# Altium schematic sheet espbat.SchDoc
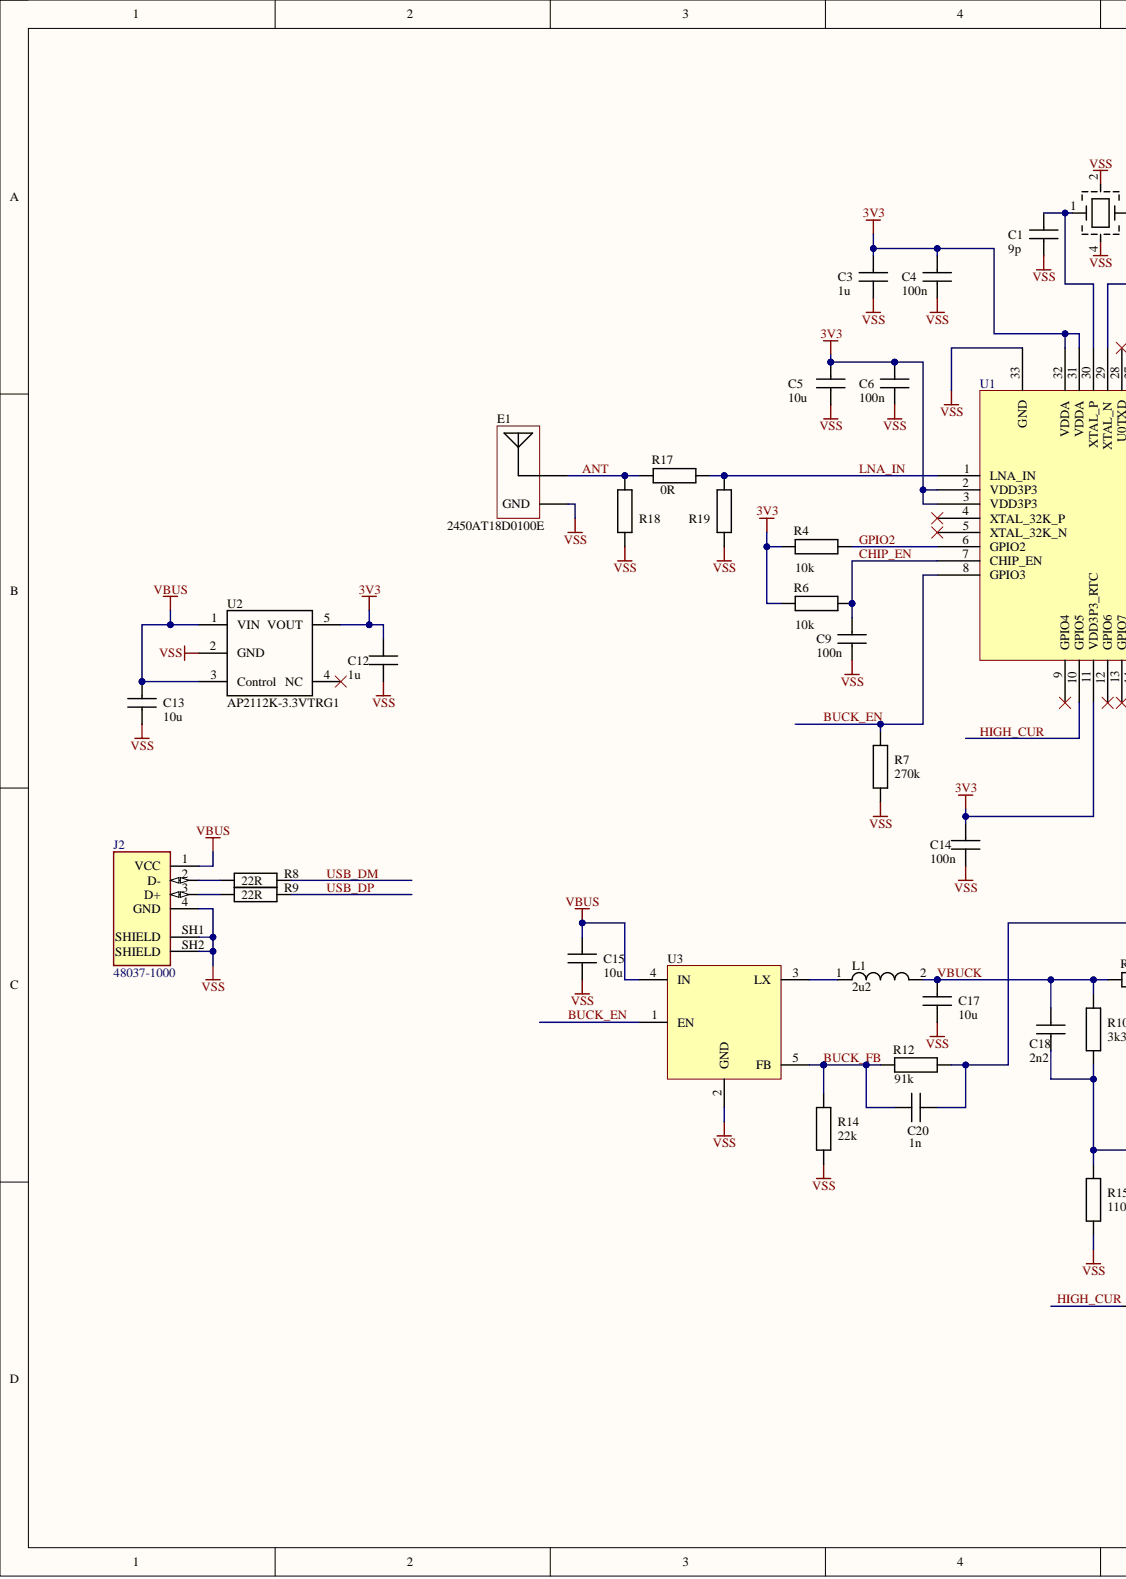
[diagram p0: espbat.SchDoc, left]
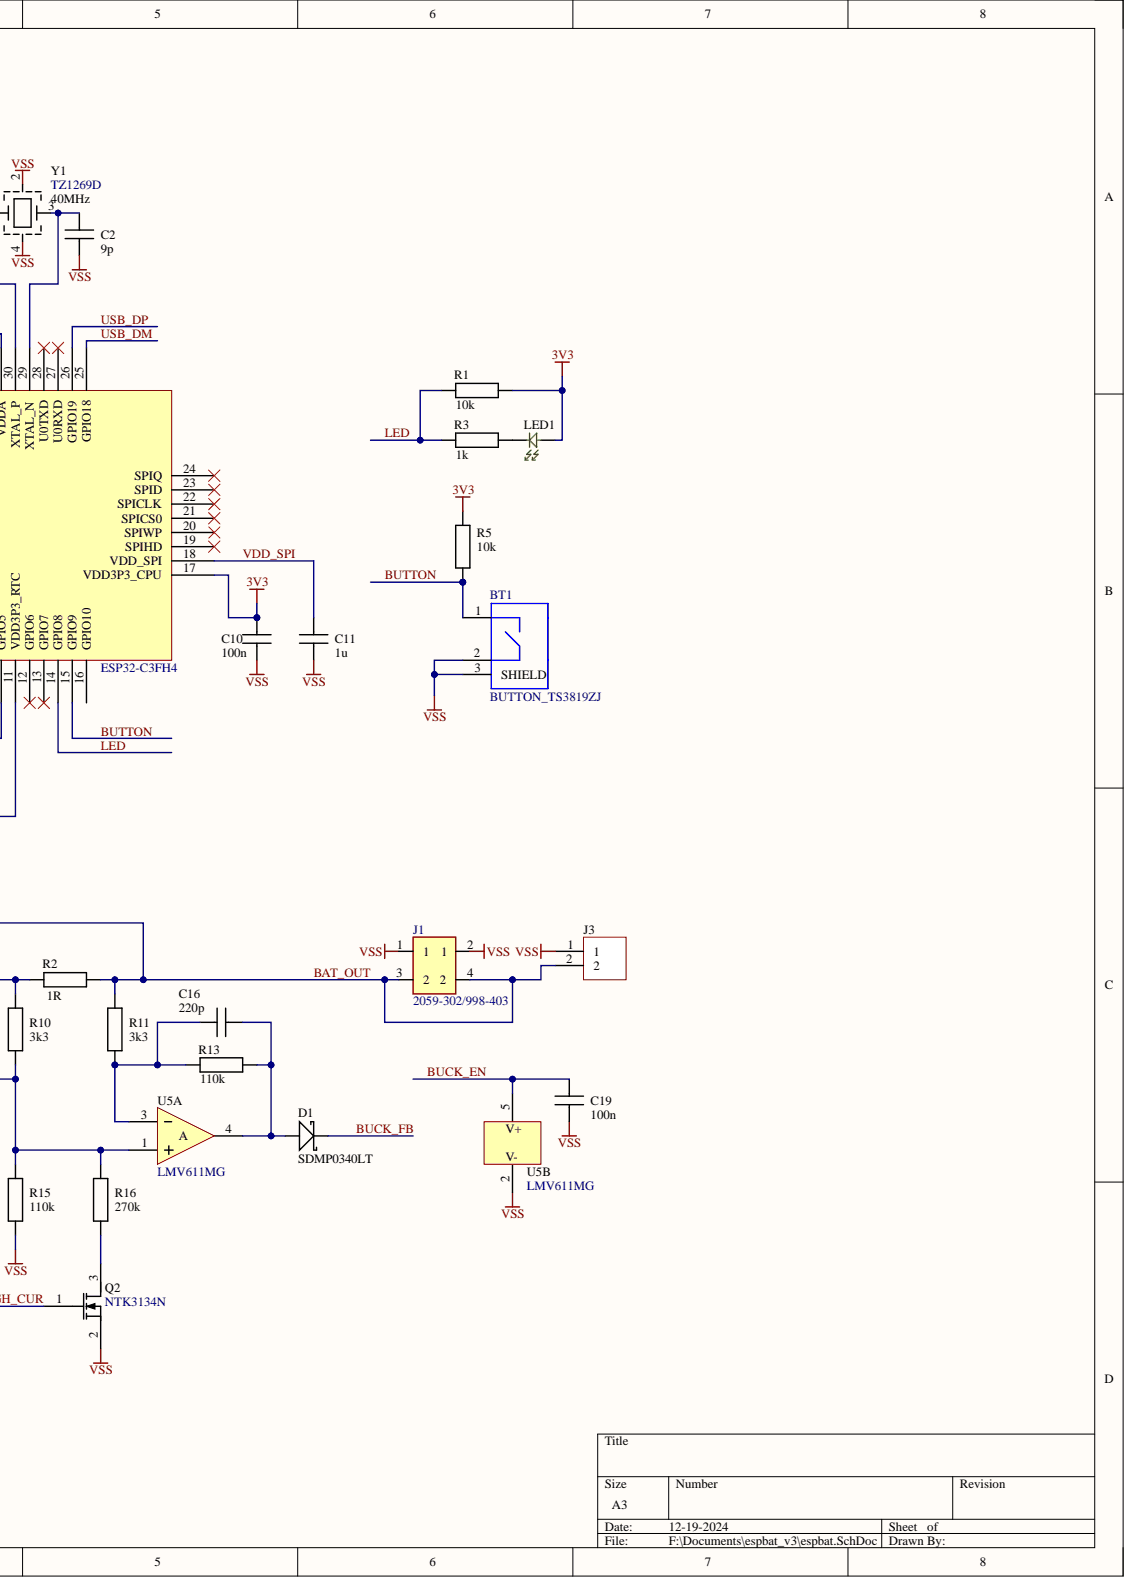
[diagram p1: espbat.SchDoc, right]

## Parts
BT1  BUTTON_TS3819ZJ  [TS3819ZJ]
C1  value 9p  [CAP_0201]  (hidden comment: CAP_0201)
C2  value 9p  [CAP_0201]  (hidden comment: CAP_0201)
C3  value 1u  [CAP_0201]  (hidden comment: CAP_0201)
C4  value 100n  [CAP_0201]  (hidden comment: CAP_0201)
C5  value 10u  [CAP_0402]  (hidden comment: CAP_0402)
C6  value 100n  [CAP_0201]  (hidden comment: CAP_0201)
C9  value 100n  [CAP_0201]  (hidden comment: CAP_0201)
C10  value 100n  [CAP_0201]  (hidden comment: CAP_0201)
C11  value 1u  [CAP_0201]  (hidden comment: CAP_0201)
C12  value 1u  [CAP_0201]  (hidden comment: CAP_0201)
C13  value 10u  [CAP_0402]  (hidden comment: CAP_0402)
C14  value 100n  [CAP_0201]  (hidden comment: CAP_0201)
C15  value 10u  [CAP_0402]  (hidden comment: CAP_0402)
C16  value 220p  [CAP_0201]  (hidden comment: CAP_0201)
C17  value 10u  [CAP_0402]  (hidden comment: CAP_0402)
C18  value 2n2  [CAP_0201]  (hidden comment: CAP_0201)
C19  value 100n  [CAP_0201]  (hidden comment: CAP_0201)
C20  value 1n  [CAP_0201]  (hidden comment: CAP_0201)
D1  SDMP0340LT  [sot523]
E1  Manufacturer_Part_Number: 2450AT18D0100E  [ANT_2450AT18D0100E_ALT]
J1  2059-302/998-403  [WAGO_2059-302/998-403]
J2  48037-1000  [MOLEX_48037-1000]
J3  CON_TERMINAL_BLOCK_2pos_2.54  [691210910002]  (value not drawn)
L1  2u2  [Inductor_Bourns-SRN2512]
LED1  (no value)  [LED_0603]
Q2  Manufacturer_Part_Number: NTK3134N  [SOT723]
R1  value 10k  [RES_0201]  (hidden comment: RES_0201)
R2  value 1R  [RES_0603]  (hidden comment: RES_0603)
R3  value 1k  [RES_0201]  (hidden comment: RES_0201)
R4  value 10k  [RES_0201]  (hidden comment: RES_0201)
R5  value 10k  [RES_0201]  (hidden comment: RES_0201)
R6  value 10k  [RES_0201]  (hidden comment: RES_0201)
R7  value 270k  [RES_0201]  (hidden comment: RES_0201)
R8  value 22R  [RES_0201]  (hidden comment: RES_0201)
R9  value 22R  [RES_0201]  (hidden comment: RES_0201)
R10  value 3k3  [RES_0201]  (hidden comment: RES_0201)
R11  value 3k3  [RES_0201]  (hidden comment: RES_0201)
R12  value 91k  [RES_0201]  (hidden comment: RES_0201)
R13  value 110k  [RES_0201]  (hidden comment: RES_0201)
R14  value 22k  [RES_0201]  (hidden comment: RES_0201)
R15  value 110k  [RES_0201]  (hidden comment: RES_0201)
R16  value 270k  [RES_0201]  (hidden comment: RES_0201)
R17  value 0R  [RES_0201]  (hidden comment: RES_0201)
R18  RES_0201  [RES_0201]  (value not drawn)
R19  RES_0201  [RES_0201]  (value not drawn)
U1  ESP32-C3FH4  [QFN50P500X500X80-32N]
U2  Manufacturer_Part_Number: AP2112K-3.3VTRG1  [SOT23-5]
U3  (no value)  [SOT23-5]
U5  Manufacturer_Part_Number: LMV611MG  [SOT353]  (hidden comment: LMV611DCK)  (parts A,B)
Y1  value 40MHz  Manufacturer_Part_Number: TZ1269D  [XTAL_2016]  (hidden comment: XTAL_2016)

## Nets
3V3: C3.2 C4.2 C5.2 C6.2 C10.2 C12.2 C14.2 LED1.A R1.2 R4.2 R5.1 R6.2 U1.2(VDD3P3) U1.3(VDD3P3) U1.11(VDD3P3_RTC) U1.17(VDD3P3_CPU) U1.31(VDDA) U1.32(VDDA) U2.5(VOUT)
VBUS: C13.2 C15.2 J2.1(VCC) U2.1(VIN) U2.3(Control) U3.4(IN)
VSS: BT1.2 BT1.3 C1.1 C2.1 C3.1 C4.1 C5.1 C6.1 C9.1 C10.1 C11.1 C12.1 C13.1 C14.1 C15.1 C17.1 C19.1 E1.2 J1.1 J1.2 J2.4(GND) J2.SH1(SHIELD) J2.SH2(SHIELD) J3.1 Q2.2 R7.1 R14.1 R15.2 R18.2 R19.2 U1.33(GND) U2.2(GND) U3.2(GND) U5.2(V-) Y1.2 Y1.4
ANT: E1.1 R17.2 R18.1
BAT_OUT: C20.2 J1.3 J1.4 J3.2 R2.2 R11.1 R12.1
BUCK_EN: C19.2 R7.2 U1.8(GPIO3) U3.1(EN) U5.5(V+)
BUCK_FB: C20.1 D1.3 R12.2 R14.2 U3.5(FB)
BUTTON: BT1.1 R5.2 U1.15(GPIO9)
CHIP_EN: C9.2 R6.1 U1.7(CHIP_EN)
GPIO2: R4.1 U1.6(GPIO2)
HIGH_CUR: Q2.1 U1.10(GPIO5)
LED: R1.1 R3.1 U1.14(GPIO8)
LNA_IN: R17.1 R19.1 U1.1(LNA_IN)
USB_DM: R8.1 U1.25(GPIO18)
USB_DP: R9.1 U1.26(GPIO19)
VBUCK: C17.2 C18.2 L1.2 R2.1 R10.1
VDD_SPI: C11.2 U1.18(VDD_SPI)
NetC1_2: C1.2 U1.30(XTAL_P) Y1.1
NetC2_2: C2.2 U1.29(XTAL_N) Y1.3
NetC16_1: C16.1 D1.1 R13.2 U5.4
NetC16_2: C16.2 R11.2 R13.1 U5.3
NetC18_1: C18.1 R10.2 R15.1 R16.1 U5.1
NetJ2_2: J2.2(D-) R8.2
NetJ2_3: J2.3(D+) R9.2
NetL1_1: L1.1 U3.3(LX)
NetLED1_C: LED1.C R3.2
NetQ2_3: Q2.3 R16.2

Not connected: U1 4(XTAL_32K_P) 5(XTAL_32K_N) 9(GPIO4) 12(GPIO6) 13(GPIO7) 16(GPIO10) 19(SPIHD) 20(SPIWP) 21(SPICS0) 22(SPICLK) 23(SPID) 24(SPIQ) 27(U0RXD) 28(U0TXD); U2 4(NC)

## Library data of the parts
BT1 = BUTTON_TS3819ZJ: Tactile Switch SPST-NO Side Actuated Surface Mount, Right Angle; Component Kind: Standard; LCSC part number: C6386917; Package: None; Price: None; Library Name: MAONLib.SchLib
D1 = DIO_SDMP0340LT: Schottky Diode, 30mA; Manufacturer: Diodes; Component Kind: Standard; LCSC part number: C460260; Library Name: FFLib.SchLib; Manufacturer_Part_Number: SDMP0340LT; Rating: 30mA; Value: SDMP0340LT
E1 = ANT-BT-3216: 2.45GHz SMD antenna 3.2x1.6mm, H=1.2mm; Manufacturer: Johanson Technology; Component Kind: Standard; Height: 1.2mm; Library Name: FFLib.SchLib; Package: 3216
J1 = 2059-302/998-403: 2059 Series 2 Position 24-18 AWG 3 mm Spacing Push Wire SMT Terminal Block; Manufacturer: Wago; Component Kind: Standard; LCSC part number: C2765060; Manufacturer_Part_Number: 2059-302/998-403; Package: None; Price: None; Library Name: MAONLib.SchLib
J2 = 48037-1000: USB-A (USB TYPE-A) USB 2.0 Plug Connector 4 Position Surface Mount, Right Angle; Through Hole; Manufacturer: Molex; Component Kind: Standard; LCSC part number: C136456; Manufacturer_Part_Number: 48037-1000; Package: None; Library Name: MAONLib.SchLib
J3 = CON_TERMINAL_BLOCK_2pos_2.54: Terminal Block 2-pin; Manufacturer: Wurth; Component Kind: Standard; Manufacturer_Part_Number: 691210910002; Library Name: MAONLib.SchLib
L1 = IND_BOURNS_SRN2512: Semi-shielded Power Inductors; Manufacturer: Bourns; Manufacturer_Part_Number: SRN2512-2R2M; Component Kind: Standard; Height: 1.2mm; LCSC part number: C22471111; Library Name: FFLib.SchLib; Value: 2u2
LED1 = LED_0603_GREEN: LED Green Vf@20mA=2.2V; Manufacturer: Avago; Manufacturer_Part_Number: HSMG-C190; Rating: 20mA; Component Kind: Standard; LCSC part number: C965806; Library Name: FFLib.SchLib; Package: 0603
Q2 = NFET_NTK3134N: N-Channel MOSFET 20V low RDS; Manufacturer: Onsemi; Component Kind: Standard; LCSC part number: C587154; Package: SOT723; Library Name: MAONLib.SchLib
R1 R3-R19 = RES_0201: SMD thick film resistor 1% 250ppm; Rating: 50mW 1%; Component Kind: Standard; Height: 0.23mm; Package: 0201; Library Name: MAONLib.SchLib
  R1 R4-R6: LCSC part number: C106225
  R3: LCSC part number: C138165
  R7 R16: LCSC part number: C158651
  R8 R9: LCSC part number: C155743
  R10 R11: LCSC part number: C274874
  R12: LCSC part number: C273303
  R13 R15: LCSC part number: C295823
  R14: LCSC part number: C474416
  R17-R19: LCSC part number: C106228
R2 = RES_0603: SMD thick film resistor 1% 100ppm; Rating: 100mW 1%; Component Kind: Standard; Height: 0.45mm; LCSC part number: C112305; Library Name: FFLib.SchLib; Package: 0603
U2 = LDO_AP2112K: Manufacturer: Diodes Inc; Component Kind: Standard; Height: 1.1mm; LCSC part number: C2688249; Library Name: FFLib.SchLib; Package: SOT23-5
U3 = IM8111S: Manufacturer: IMS Semi; Component Kind: Standard; Height: 1.1mm; LCSC part number: C479072; Manufacturer_Part_Number: IM8111S; Package: SOT-23-5; Library Name: MAONLib.SchLib
U5 = LMV611DCK: OP Amplifier; Manufacturer: TI; Component Kind: Standard; Height: 1.1mm; LCSC part number: C2057880; Package: SC70; Library Name: MAONLib.SchLib
Y1 = XTAL_2016: XTAL 2016; Manufacturer: TST; Component Kind: Standard; LCSC part number: C501798; Package: 2016; Library Name: MAONLib.SchLib
C1-C4 C6 C9-C12 C14 C16 C18-C20 = CAP_0201: Component Kind: Standard; Height: 0.3mm; Package: 0201; Library Name: MAONLib.SchLib
  C1 C2: LCSC part number: C161444
  C3 C11 C12: LCSC part number: C5350803
  C4 C6 C9 C10 C14 C19: LCSC part number: C284966
  C16: LCSC part number: C281748
  C18: LCSC part number: C161488
  C20: LCSC part number: C161371
C5 C13 C15 C17 = CAP_0402: Component Kind: Standard; Height: 0.5mm; LCSC part number: C315248; Package: 0402; Library Name: MAONLib.SchLib
U1 = ESP32-C3FH4: Component Kind: Standard; Description: ESP32-C3FH4; LCSC part number: C2858491; Library Name: MAONLib.SchLib
